# Altium schematic sheet SCH004 - VSC742x Switch PHY ports 1-12.SchDoc
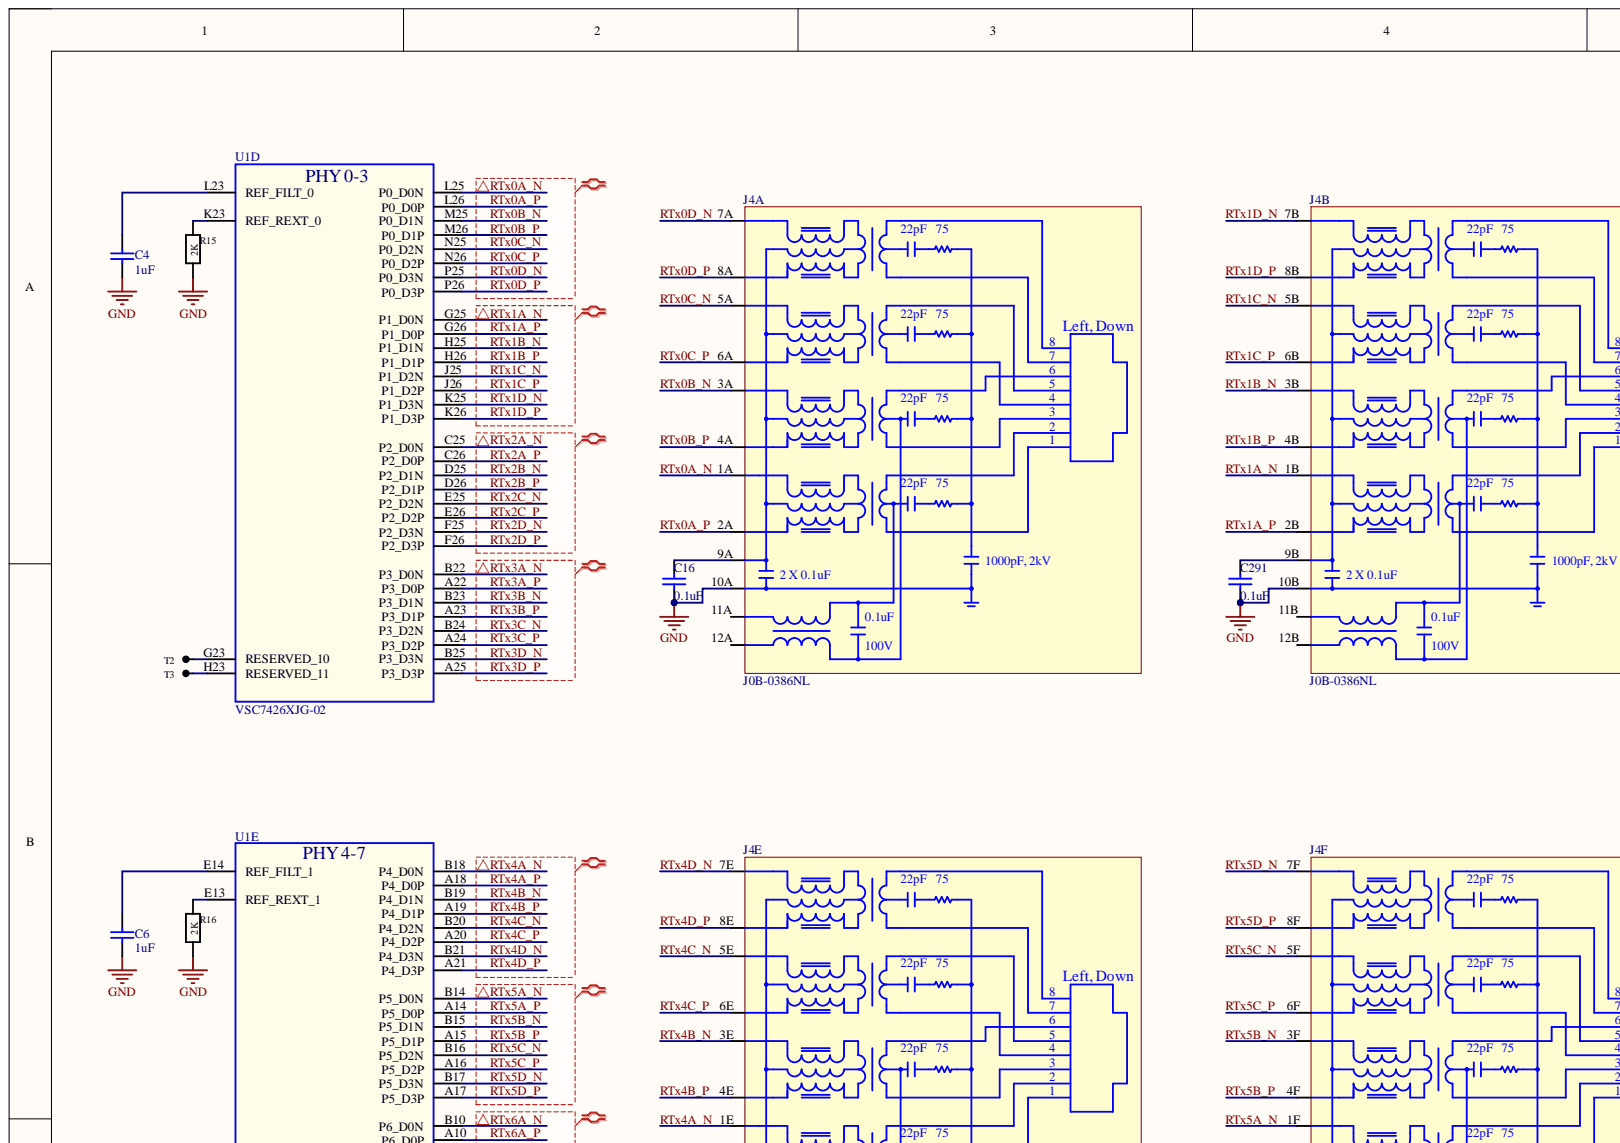
[diagram p0: SCH004 - VSC742x Switch PHY ports 1-12.SchDoc, top left]
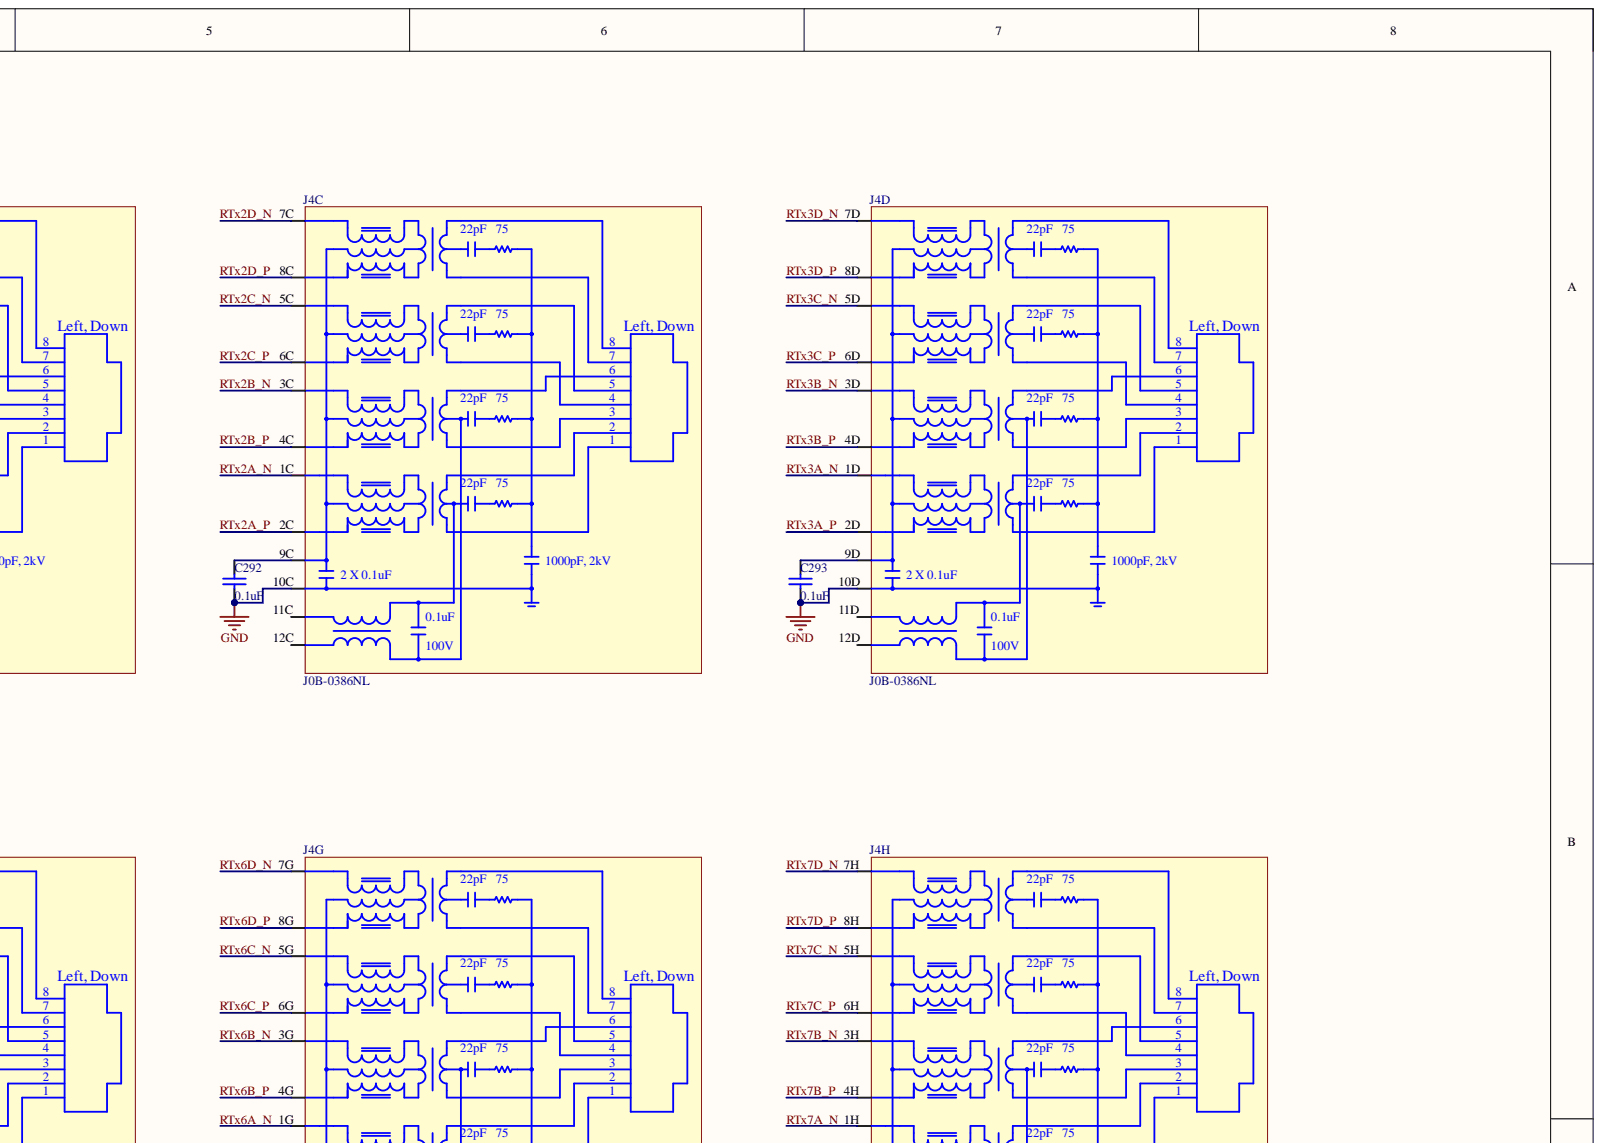
[diagram p1: SCH004 - VSC742x Switch PHY ports 1-12.SchDoc, top right]
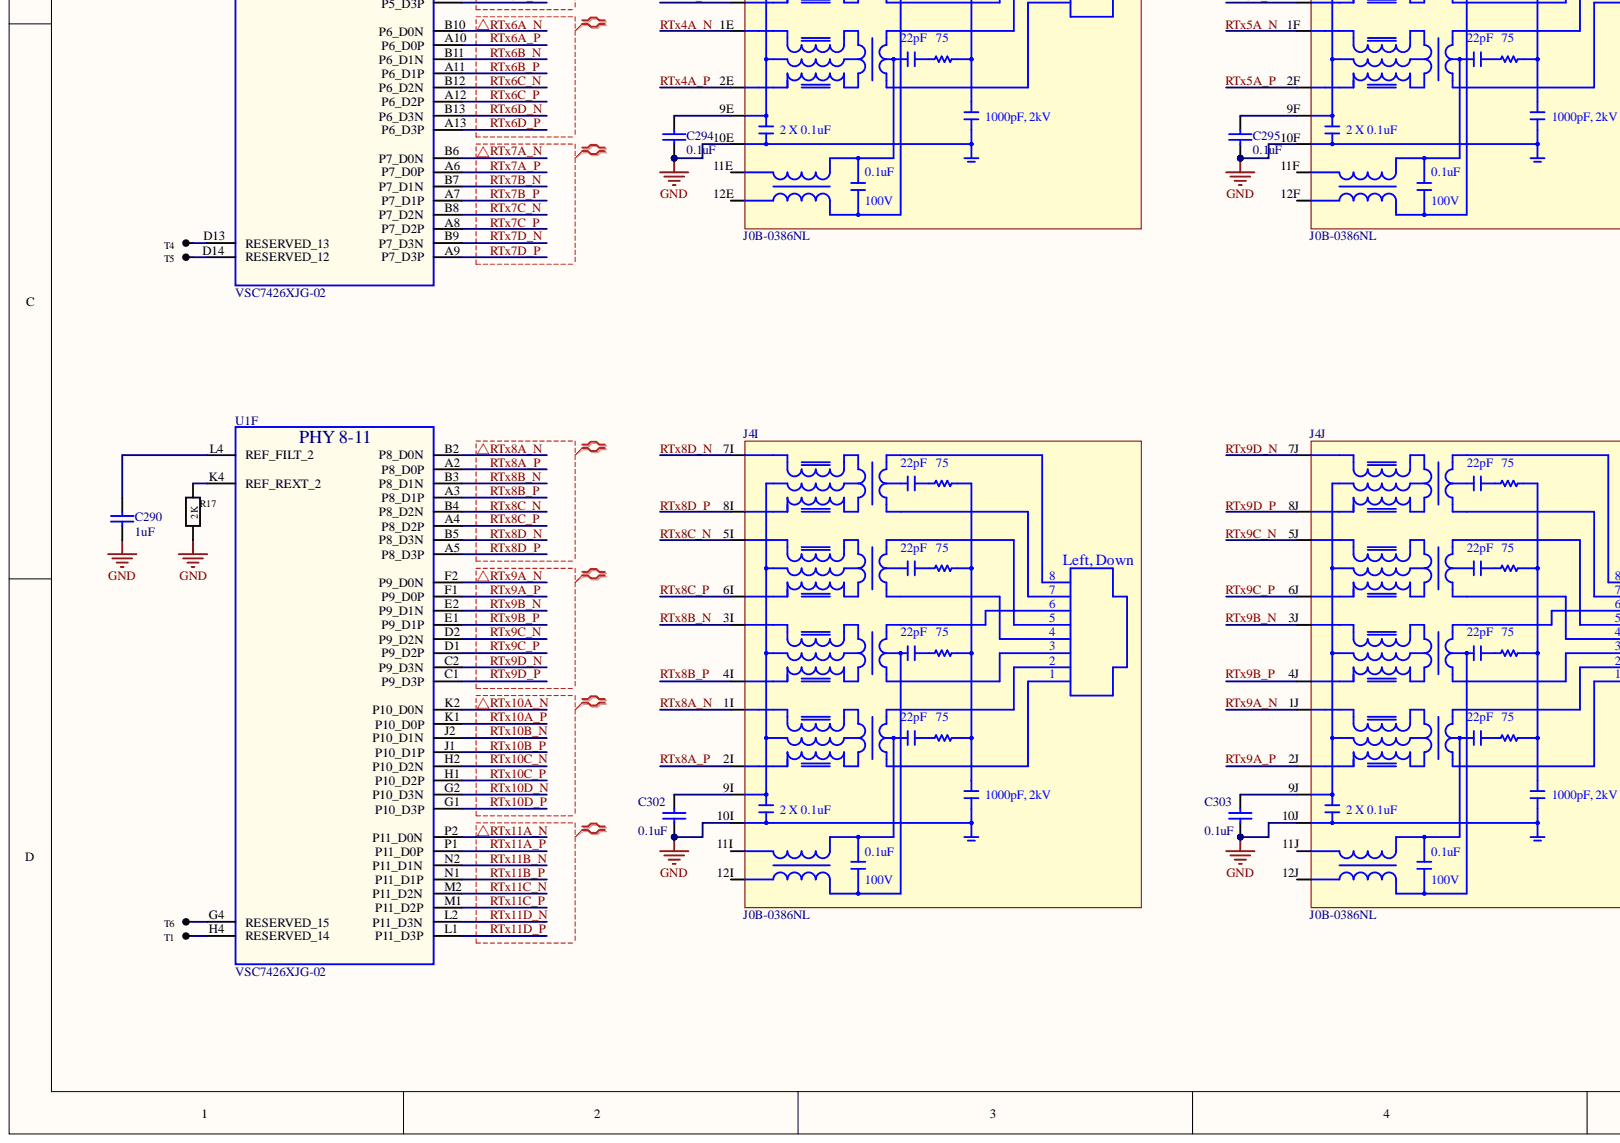
[diagram p2: SCH004 - VSC742x Switch PHY ports 1-12.SchDoc, bottom left]
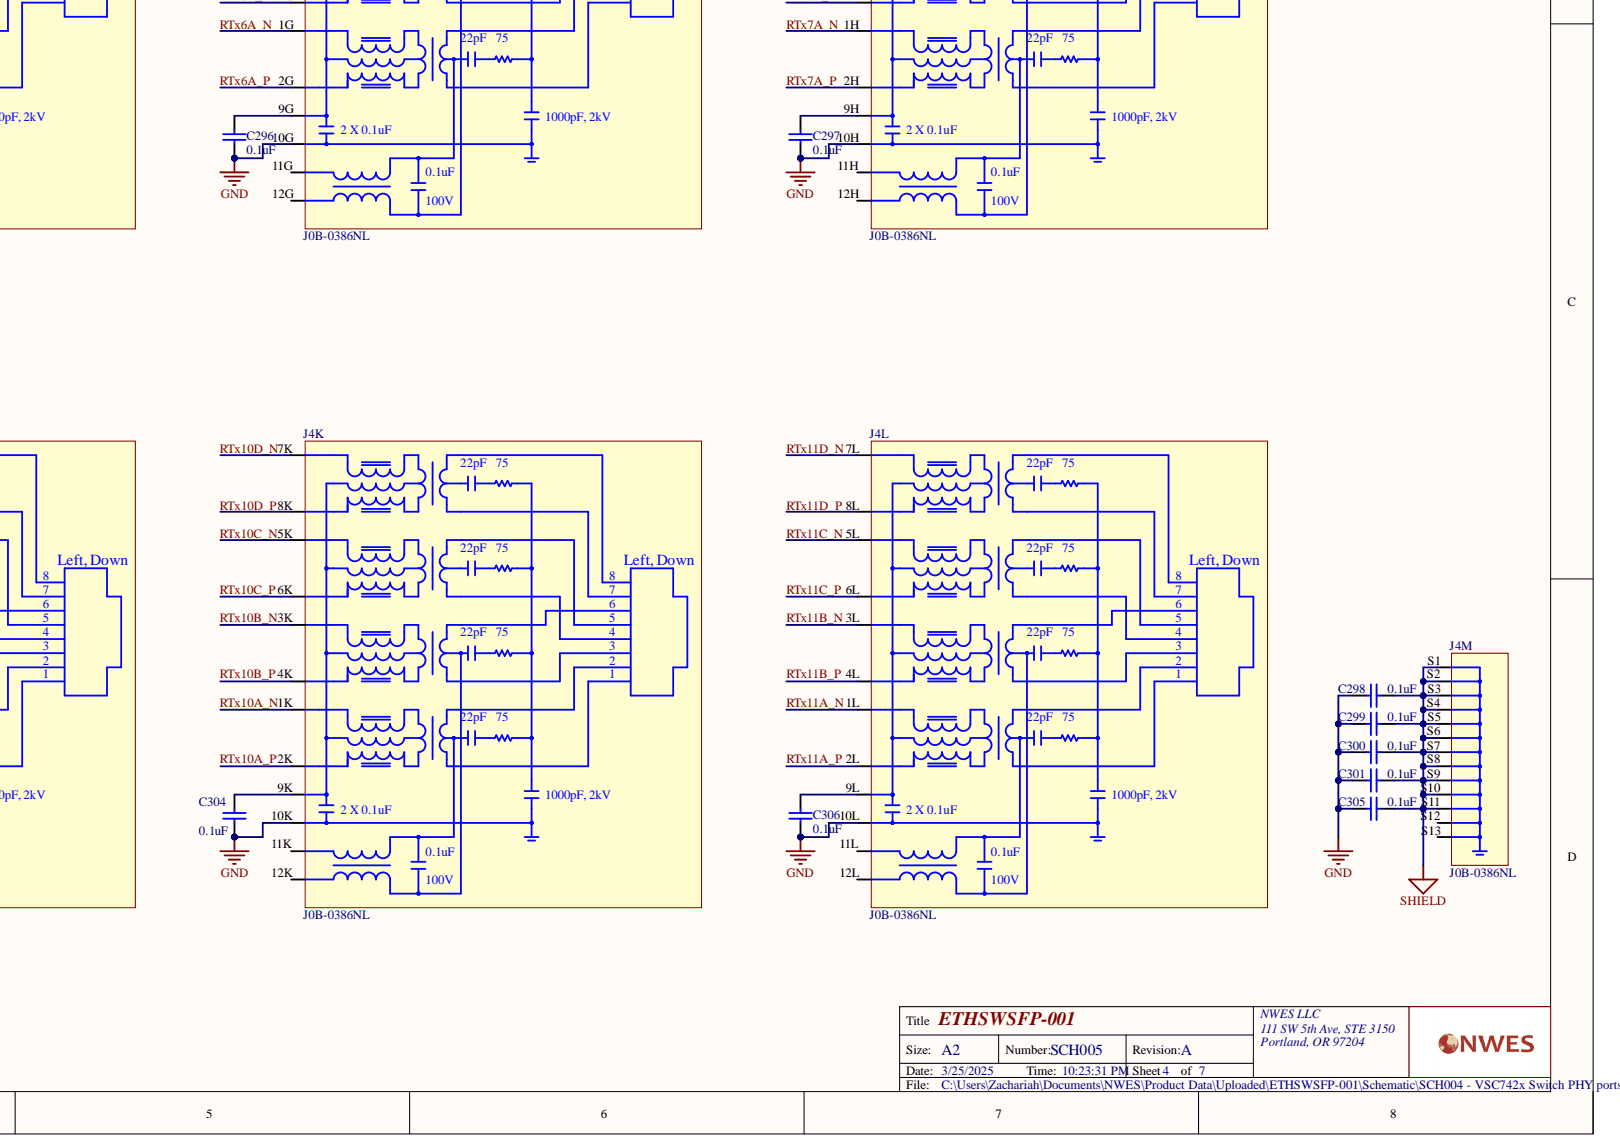
[diagram p3: SCH004 - VSC742x Switch PHY ports 1-12.SchDoc, bottom right]

## Parts
C4  1uF  [CAPC1005X61USR]
C6  1uF  [CAPC1005X61USR]
C16  0.1uF  [CAPC1005X61USR]
C290  1uF  [CAPC1005X61USR]
C291  0.1uF  [CAPC1005X61USR]
C292  0.1uF  [CAPC1005X61USR]
C293  0.1uF  [CAPC1005X61USR]
C294  0.1uF  [CAPC1005X61USR]
C295  0.1uF  [CAPC1005X61USR]
C296  0.1uF  [CAPC1005X61USR]
C297  0.1uF  [CAPC1005X61USR]
C298  0.1uF  [CAPC1005X61USR]
C299  0.1uF  [CAPC1005X61USR]
C300  0.1uF  [CAPC1005X61USR]
C301  0.1uF  [CAPC1005X61USR]
C302  0.1uF  [CAPC1005X61USR]
C303  0.1uF  [CAPC1005X61USR]
C304  0.1uF  [CAPC1005X61USR]
C305  0.1uF  [CAPC1005X61USR]
C306  0.1uF  [CAPC1005X61USR]
J4  J0B-0386NL  [J0B-0386NL]  (parts A,B,C,D,E,F,G,H,I,J,K,L,M)
R15  2K  [RESC1005X40USR]
R16  2K  [RESC1005X40USR]
R17  2K  [RESC1005X40USR]
T1  TP_S  [TP 1mm]  (value not drawn)  (no BOM)
T2  TP_S  [TP 1mm]  (value not drawn)  (no BOM)
T3  TP_S  [TP 1mm]  (value not drawn)  (no BOM)
T4  TP_S  [TP 1mm]  (value not drawn)  (no BOM)
T5  TP_S  [TP 1mm]  (value not drawn)  (no BOM)
T6  TP_S  [TP 1mm]  (value not drawn)  (no BOM)
U1  VSC7426XJG-02  [BGA672C100P26X26_2700X2700X244]  (parts D,E,F)

## Nets
GND: C4.1 C6.1 C16.2 C290.1 C291.2 C292.2 C293.2 C294.1 C295.1 C296.1 C297.1 C298.1 C299.1 C300.1 C301.1 C302.1 C303.1 C304.1 C305.1 C306.1 J4.10A J4.10B J4.10C J4.10D J4.10E J4.10F J4.10G J4.10H J4.10I J4.10J J4.10K J4.10L R15.2 R16.2 R17.2
SHIELD: C298.2 C299.2 C300.2 C301.2 C305.2 J4.S1 J4.S2 J4.S3 J4.S4 J4.S5 J4.S6 J4.S7 J4.S8 J4.S9 J4.S10 J4.S11
RTx0A_N: J4.1A U1.L25(P0_D0N)
RTx0A_P: J4.2A U1.L26(P0_D0P)
RTx0B_N: J4.3A U1.M25(P0_D1N)
RTx0B_P: J4.4A U1.M26(P0_D1P)
RTx0C_N: J4.5A U1.N25(P0_D2N)
RTx0C_P: J4.6A U1.N26(P0_D2P)
RTx0D_N: J4.7A U1.P25(P0_D3N)
RTx0D_P: J4.8A U1.P26(P0_D3P)
RTx1A_N: J4.1B U1.G25(P1_D0N)
RTx1A_P: J4.2B U1.G26(P1_D0P)
RTx1B_N: J4.3B U1.H25(P1_D1N)
RTx1B_P: J4.4B U1.H26(P1_D1P)
RTx1C_N: J4.5B U1.J25(P1_D2N)
RTx1C_P: J4.6B U1.J26(P1_D2P)
RTx1D_N: J4.7B U1.K25(P1_D3N)
RTx1D_P: J4.8B U1.K26(P1_D3P)
RTx2A_N: J4.1C U1.C25(P2_D0N)
RTx2A_P: J4.2C U1.C26(P2_D0P)
RTx2B_N: J4.3C U1.D25(P2_D1N)
RTx2B_P: J4.4C U1.D26(P2_D1P)
RTx2C_N: J4.5C U1.E25(P2_D2N)
RTx2C_P: J4.6C U1.E26(P2_D2P)
RTx2D_N: J4.7C U1.F25(P2_D3N)
RTx2D_P: J4.8C U1.F26(P2_D3P)
RTx3A_N: J4.1D U1.B22(P3_D0N)
RTx3A_P: J4.2D U1.A22(P3_D0P)
RTx3B_N: J4.3D U1.B23(P3_D1N)
RTx3B_P: J4.4D U1.A23(P3_D1P)
RTx3C_N: J4.5D U1.B24(P3_D2N)
RTx3C_P: J4.6D U1.A24(P3_D2P)
RTx3D_N: J4.7D U1.B25(P3_D3N)
RTx3D_P: J4.8D U1.A25(P3_D3P)
RTx4A_N: J4.1E U1.B18(P4_D0N)
RTx4A_P: J4.2E U1.A18(P4_D0P)
RTx4B_N: J4.3E U1.B19(P4_D1N)
RTx4B_P: J4.4E U1.A19(P4_D1P)
RTx4C_N: J4.5E U1.B20(P4_D2N)
RTx4C_P: J4.6E U1.A20(P4_D2P)
RTx4D_N: J4.7E U1.B21(P4_D3N)
RTx4D_P: J4.8E U1.A21(P4_D3P)
RTx5A_N: J4.1F U1.B14(P5_D0N)
RTx5A_P: J4.2F U1.A14(P5_D0P)
RTx5B_N: J4.3F U1.B15(P5_D1N)
RTx5B_P: J4.4F U1.A15(P5_D1P)
RTx5C_N: J4.5F U1.B16(P5_D2N)
RTx5C_P: J4.6F U1.A16(P5_D2P)
RTx5D_N: J4.7F U1.B17(P5_D3N)
RTx5D_P: J4.8F U1.A17(P5_D3P)
RTx6A_N: J4.1G U1.B10(P6_D0N)
RTx6A_P: J4.2G U1.A10(P6_D0P)
RTx6B_N: J4.3G U1.B11(P6_D1N)
RTx6B_P: J4.4G U1.A11(P6_D1P)
RTx6C_N: J4.5G U1.B12(P6_D2N)
RTx6C_P: J4.6G U1.A12(P6_D2P)
RTx6D_N: J4.7G U1.B13(P6_D3N)
RTx6D_P: J4.8G U1.A13(P6_D3P)
RTx7A_N: J4.1H U1.B6(P7_D0N)
RTx7A_P: J4.2H U1.A6(P7_D0P)
RTx7B_N: J4.3H U1.B7(P7_D1N)
RTx7B_P: J4.4H U1.A7(P7_D1P)
RTx7C_N: J4.5H U1.B8(P7_D2N)
RTx7C_P: J4.6H U1.A8(P7_D2P)
RTx7D_N: J4.7H U1.B9(P7_D3N)
RTx7D_P: J4.8H U1.A9(P7_D3P)
RTx8A_N: J4.1I U1.B2(P8_D0N)
RTx8A_P: J4.2I U1.A2(P8_D0P)
RTx8B_N: J4.3I U1.B3(P8_D1N)
RTx8B_P: J4.4I U1.A3(P8_D1P)
RTx8C_N: J4.5I U1.B4(P8_D2N)
RTx8C_P: J4.6I U1.A4(P8_D2P)
RTx8D_N: J4.7I U1.B5(P8_D3N)
RTx8D_P: J4.8I U1.A5(P8_D3P)
RTx9A_N: J4.1J U1.F2(P9_D0N)
RTx9A_P: J4.2J U1.F1(P9_D0P)
RTx9B_N: J4.3J U1.E2(P9_D1N)
RTx9B_P: J4.4J U1.E1(P9_D1P)
RTx9C_N: J4.5J U1.D2(P9_D2N)
RTx9C_P: J4.6J U1.D1(P9_D2P)
RTx9D_N: J4.7J U1.C2(P9_D3N)
RTx9D_P: J4.8J U1.C1(P9_D3P)
RTx10A_N: J4.1K U1.K2(P10_D0N)
RTx10A_P: J4.2K U1.K1(P10_D0P)
RTx10B_N: J4.3K U1.J2(P10_D1N)
RTx10B_P: J4.4K U1.J1(P10_D1P)
RTx10C_N: J4.5K U1.H2(P10_D2N)
RTx10C_P: J4.6K U1.H1(P10_D2P)
RTx10D_N: J4.7K U1.G2(P10_D3N)
RTx10D_P: J4.8K U1.G1(P10_D3P)
RTx11A_N: J4.1L U1.P2(P11_D0N)
RTx11A_P: J4.2L U1.P1(P11_D0P)
RTx11B_N: J4.3L U1.N2(P11_D1N)
RTx11B_P: J4.4L U1.N1(P11_D1P)
RTx11C_N: J4.5L U1.M2(P11_D2N)
RTx11C_P: J4.6L U1.M1(P11_D2P)
RTx11D_N: J4.7L U1.L2(P11_D3N)
RTx11D_P: J4.8L U1.L1(P11_D3P)
NetC4_2: C4.2 U1.L23(REF_FILT_0)
NetC6_2: C6.2 U1.E14(REF_FILT_1)
NetC16_1: C16.1 J4.9A
NetC290_2: C290.2 U1.L4(REF_FILT_2)
NetC291_1: C291.1 J4.9B
NetC292_1: C292.1 J4.9C
NetC293_1: C293.1 J4.9D
NetC294_2: C294.2 J4.9E
NetC295_2: C295.2 J4.9F
NetC296_2: C296.2 J4.9G
NetC297_2: C297.2 J4.9H
NetC302_2: C302.2 J4.9I
NetC303_2: C303.2 J4.9J
NetC304_2: C304.2 J4.9K
NetC306_2: C306.2 J4.9L
NetR15_1: R15.1 U1.K23(REF_REXT_0)
NetR16_1: R16.1 U1.E13(REF_REXT_1)
NetR17_1: R17.1 U1.K4(REF_REXT_2)
NetT1_1: T1.1 U1.H4(RESERVED_14)
NetT2_1: T2.1 U1.G23(RESERVED_10)
NetT3_1: T3.1 U1.H23(RESERVED_11)
NetT4_1: T4.1 U1.D13(RESERVED_13)
NetT5_1: T5.1 U1.D14(RESERVED_12)
NetT6_1: T6.1 U1.G4(RESERVED_15)

Not connected: J4 11A 11B 11C 11D 11E 11F 11G 11H 11I 11J 11K 11L 12A 12B 12C 12D 12E 12F 12G 12H 12I 12J 12K 12L S12 S13

## Library data of the parts
C4 C6 C290 = CAP CER 1UF 25V X5R 0402: Manufacturer: Murata Electronics; Manufacturer Part Number: GRM155R61E105MA12D; Component Kind: Standard; Description: CAP CER 1UF 25V X5R 0402; Package: 0402; Supplier 1: Digi-Key; Supplier Part Number 1: 490-10018-1-ND; value: 1uF; Library Name: 15-port switch V1.SCHLIB
C16 C291-C306 = CAP CER 0.1UF 50V X7R 0402: Manufacturer: Murata Electronics; Manufacturer Part Number: GRM155R71H104KE14D; Component Kind: Standard; Description: CAP CER 0.1UF 50V X7R 0402; Package: 0402; Supplier 1: Digi-Key; Supplier Part Number 1: 490-10700-2-ND; value: 0.1uF; Library Name: 15-port switch V1.SCHLIB
J4 = J0B-0386NL: CONN JACK 12PORT 1000 BASE-T PCB; Manufacturer: Pulse Electronics Network; Component Kind: Standard; Library Name: 15-port switch V1.SCHLIB; Manufacturer Part Number: J0B-0386NL; Supplier 1: Digi-Key; Supplier Part Number 1: 553-3255-ND
R15-R17 = RES 2K OHM 1% 1/16W 0402: Manufacturer: Yageo; Manufacturer Part Number: RC0402FR-072KL; Component Kind: Standard; Description: RES 2K OHM 1% 1/16W 0402; Height: 0.40mm; Package: 0402; Supplier 1: Digi-Key; Supplier Part Number 1: 311-2KLRCT-ND; Value: 2K; Library Name: 15-port switch V1.SCHLIB
T1-T6 = TP_S: test point; Library Name: 26-port switch.SCHLIB
U1 = VSC7426XJG-02: IC TELECOM INTERFACE 672BGA; Manufacturer: Microchip Technology; Geometry.Height: 2.44mm; Component Kind: Standard; Manufacturer Part Number: VSC7426XJG-02; Supplier Part Number 1: VSC7426XJG-02-ND; Library Name: 15-port switch V1.SCHLIB
